# Altium schematic sheet DMotor_Schematic_page1.SchDoc
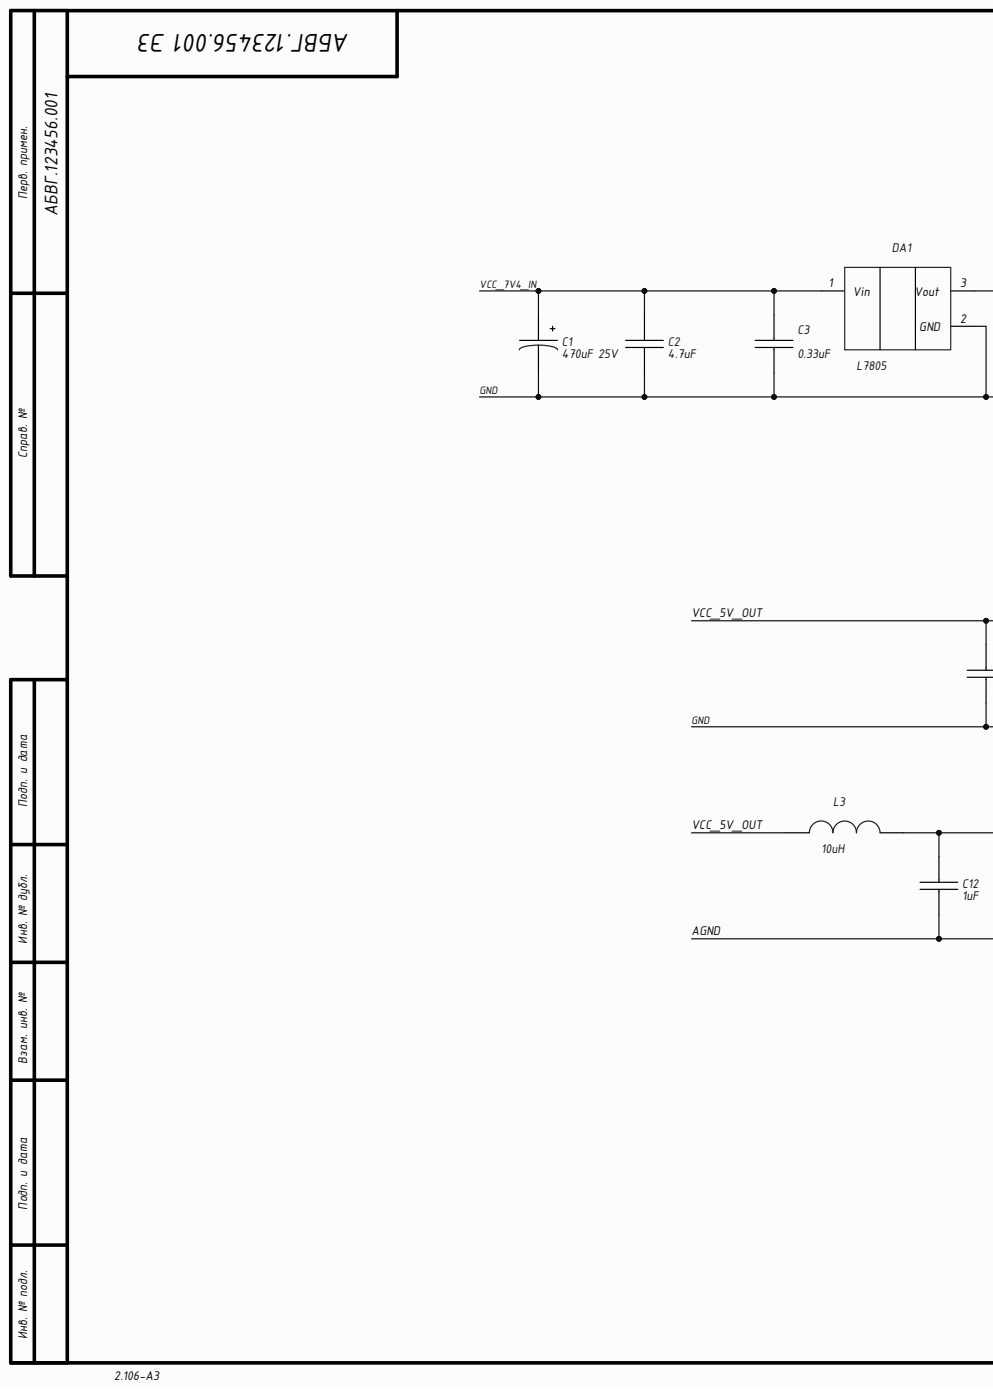
[diagram p0: DMotor_Schematic_page1.SchDoc, left]
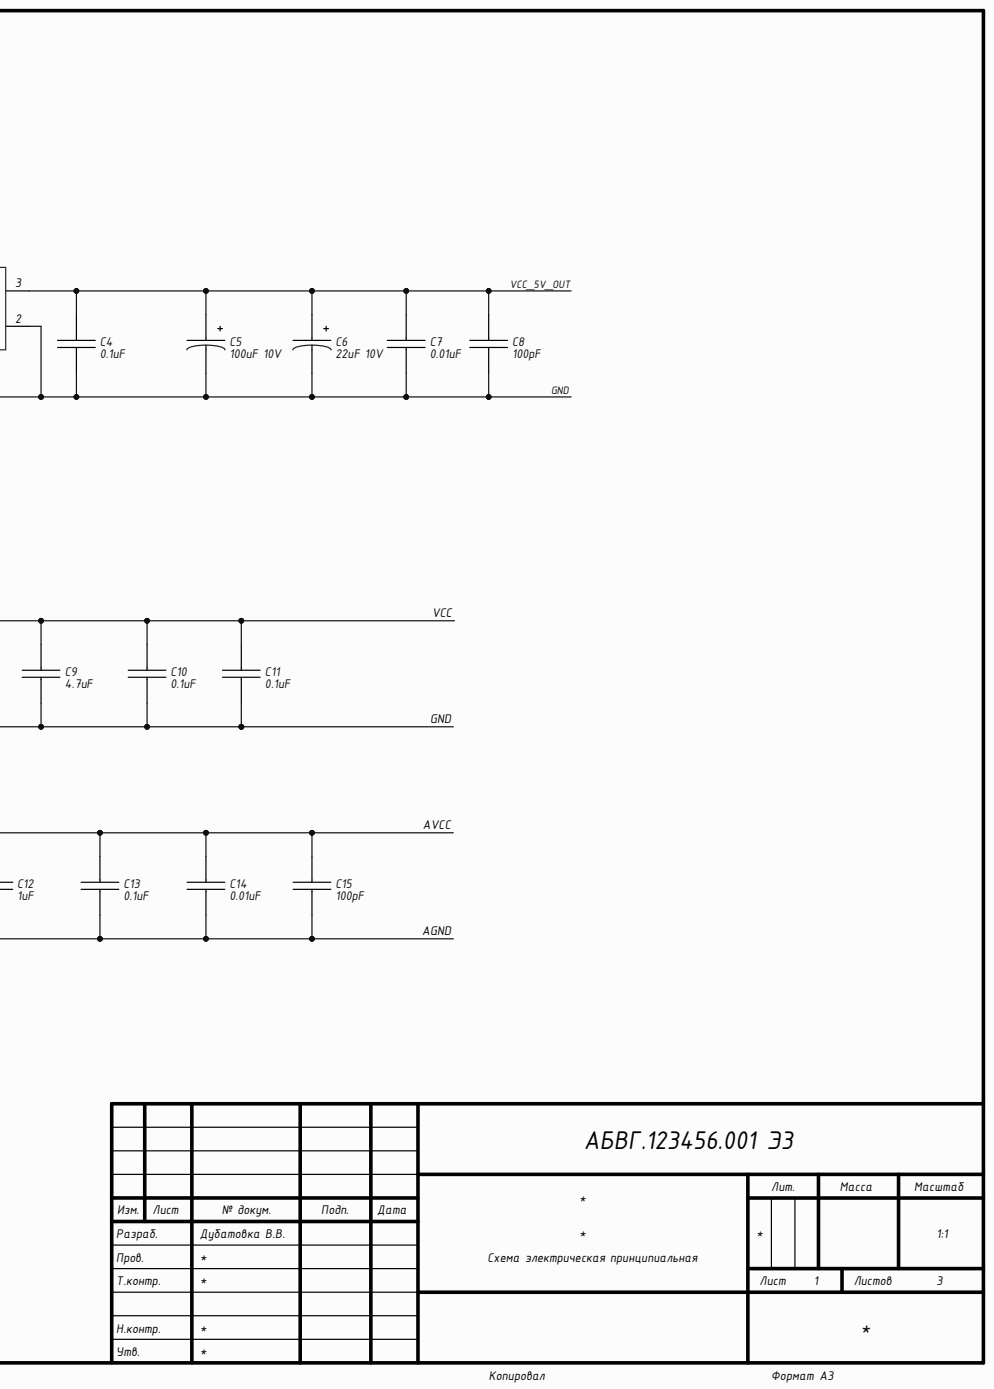
[diagram p1: DMotor_Schematic_page1.SchDoc, right]

## Parts
C1  470uF 25V  [CapElec_8x16_3.5mm]
C2  4.7uF  [C0603]
C3  0.33uF  [C0603]
C4  0.1uF  [C0603]
C5  100uF 10V  [CapElec_5x11_2mm]
C6  22uF 10V  [TANT-B]
C7  0.01uF  [C0603]
C8  100pF  [C0603]
C9  4.7uF  [C0603]
C10  0.1uF  [C0603]
C11  0.1uF  [C0603]
C12  1uF  [C0603]
C13  0.1uF  [C0603]
C14  0.01uF  [C0603]
C15  100pF  [C0603]
DA1  L7805  [TO-220A]
L3  10uH  [IND1206]

## Nets
AGND: C12.2 C13.2 C14.2 C15.2
AVCC: C12.1 C13.1 C14.1 C15.1 L3.2
GND: C1.2 C2.2 C3.2 C4.2 C5.2 C6.2 C7.2 C8.2 C9.2 C10.2 C11.2 DA1.2
VCC: C4.1 C5.1 C6.1 C7.1 C8.1 C9.1 C10.1 C11.1 DA1.3 L3.1
VCC_7V4_IN: C1.1 C2.1 C3.1 DA1.1

## Library data of the parts
C1 = Capacitor_Elec: Manufacturer: JB; Model: 25V 20%; Case: 8x16_3.5mm; Component Kind: Standard; HelpURL: https://www.chipdip.by/product0/9000565915; Library Name: Board_lib.IntLib; Value: 470uF 25V
C2-C4 C7-C15 = Capasitor: Case: C0603; Component Kind: Standard; Library Name: Board_lib.IntLib
  C2 C9: Value: 4.7uF
  C3: Value: 0.33uF
  C4 C10 C11 C13: Value: 0.1uF
  C7 C14: Value: 0.01uF
  C8 C15: Value: 100pF
  C12: Value: 1uF
C5 = Capacitor_Elec: Manufacturer: JB; Model: 10V 20%; Case: 5x11_2mm; Component Kind: Standard; HelpURL: https://www.chipdip.by/product0/9000565886; Library Name: Board_lib.IntLib; Value: 100uF 10V
C6 = Capasitor_Tantalum: Model: 10V 10%; Case: TANT-B; Component Kind: Standard; HelpURL: https://www.chipdip.by/product0/9000502415; Library Name: Board_lib.IntLib; Manufacturer: -; Value: 22uF 10V
DA1 = L75xx: Linear Voltage Regulator IC  1 Output  1.5A TO-220AB; Manufacturer: STMicroelectronics; Case: TO-220; Component Kind: Standard; HelpURL: https://www.digikey.com/product-detail/en/stmicroelectronics/L7805CV/497-1443-5-ND/585964; Library Name: Board_lib.IntLib; Model: L7805
L3 = Inductivity: Component Kind: Standard; Library Name: Board_lib.IntLib; Value: 10uH
